# Altium schematic sheet Z5 - RF Board.SchDoc
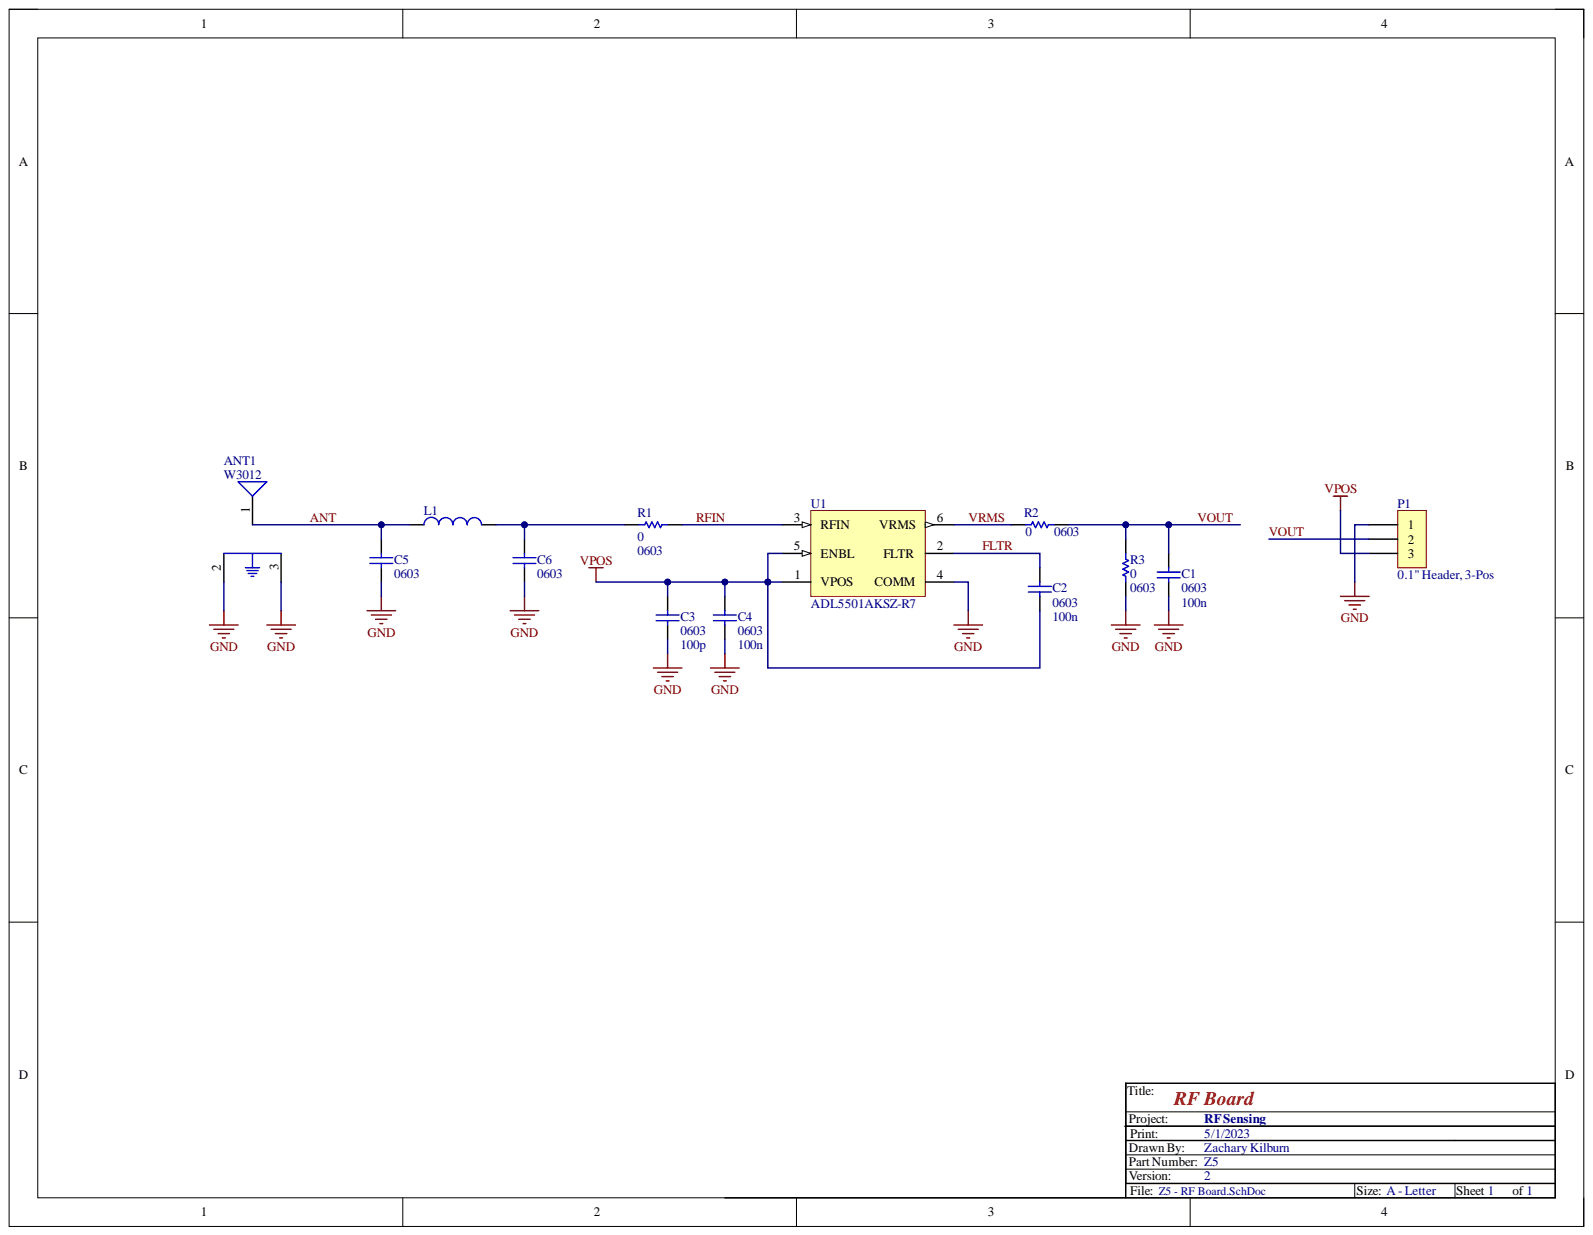
[diagram p0: Z5 - RF Board.SchDoc]

## Parts
ANT1  W3012  [W3012]
C1  value 100n  Case-EIA: 0603  [CAPC0603(1608)100_M]  (hidden comment: CAP 100nF 16V 0603(1608))
C2  value 100n  Case-EIA: 0603  [CAPC0603(1608)100_M]  (hidden comment: CAP 100nF 16V 0603(1608))
C3  value 100p  Case-EIA: 0603  [CAPC0603(1608)100_N]  (hidden comment: CAP 100pF 16V 0603(1608))
C4  value 100n  Case-EIA: 0603  [CAPC0603(1608)100_M]  (hidden comment: CAP 100nF 16V 0603(1608))
C5  Case-EIA: 0603  [CAPC0603(1608)100_L]  (hidden comment: CAP 1.5pF 16V 0603(1608))
C6  Case-EIA: 0603  [CAPC0603(1608)100_L]  (hidden comment: CAP 1.5pF 16V 0603(1608))
L1  Generic 0603 Inductor  [PCC-0603-IND-N]  (value not drawn)
P1  0.1" Header, 3-Pos  [3 Pin 0.1" R/A]
R1  value 0  Case-EIA: 0603  [RESC0603(1608)_N]  (hidden comment: Jumper 0603(1608))
R2  value 0  Case-EIA: 0603  [RESC0603(1608)_N]  (hidden comment: Jumper 0603(1608))
R3  value 0  Case-EIA: 0603  [RESC0603(1608)_N]  (hidden comment: Jumper 0603(1608))
U1  ADL5501AKSZ-R7  [ADI-KS-6_L]

## Nets
GND: ANT1.2 ANT1.3 C1.1 C3.2 C4.1 C5.1 C6.1 P1.1 R3.1 U1.4(COMM)
VPOS: C2.1 C3.1 C4.2 P1.3 U1.1(VPOS) U1.5(ENBL)
ANT: ANT1.1 C5.2 L1.1
FLTR: C2.2 U1.2(FLTR)
RFIN: R1.1 U1.3(RFIN)
VOUT: C1.2 P1.2 R2.1 R3.2
VRMS: R2.2 U1.6(VRMS)
NetC6_2: C6.2 L1.2 R1.2

## Library data of the parts
C1 C2 C4 = CMP-1035-02583-1: CAP 100nF 16V ±5% 0603 (1608 Metric) Thickness 1mm SMD; Case-Metric: 1608; Tolerance: Â±5%; Component Kind: Standard; Library Name: Altium Content Vault; Max Thickness: 1 mm; Rated Voltage: 16 V; Technology: SMT
C3 = CMP-1035-01257-1: CAP 100pF 16V ±1% 0603 (1608 Metric) Thickness 1mm SMD; Case-Metric: 1608; Tolerance: Â±1%; Component Kind: Standard; Library Name: Altium Content Vault; Max Thickness: 1 mm; Rated Voltage: 16 V; Technology: SMT
C5 C6 = CMP-1035-00091-1: CAP 1.5pF 16V ±0.1pF 0603 (1608 Metric) Thickness 1mm SMD; Case-Metric: 1608; Tolerance: Â±0.1pF; Component Kind: Standard; Library Name: Altium Content Vault; Max Thickness: 1 mm; Rated Voltage: 16 V; Technology: SMT; Value: 1.5pF
L1 = 0603 Inductor: Component Kind: Standard; Library Name: Inductors.SchLib; Package: 0603
P1 = 3-Pos 0.1" Header RA: Manufacturer: Samtec; PartNumber: TSW-103-08-F-S-RA; Component Kind: Standard; ComponentLink1Description: Datasheet; ComponentLink1URL: http://suddendocs.samtec.com/catalog_english/tsw_th.pdf; ComponentLink2Description: Package Specification; ComponentLink2URL: http://suddendocs.samtec.com/prints/tsw-xxx-xx-x-x-xx-xxx-footprint.pdf; Library Name: Headers.SchLib
R1-R3 = CMP-1012-00001-1: Jumper 0603 (1608 Metric); Case-Metric: 1608; Power: None; Component Kind: Standard; Library Name: Altium Content Vault; Technology: SMT; Tolerance: None
U1 = CMP-0284-00071-1: 50 MHz to 6 GHz TruPwr Detector, 6µs Response Time, KS-6, Pb-Free, 3000 Reel; Dynamic Range (dB): 30dB; Output Response: Linear in Voltage; Product Description: Reduced Size, Improved Temp Stability; Response Time (ns typ): 6Âµs; RF Frequency (MHz): 6GHz; Supply Current: 1.1mA; Temp Stability (dB): 250mdB; Voltage Supply (V): 2.7 to 5.5; Code_JEDEC: MO-203-AB; Component Kind: Standard; ComponentLink1Description: Manufacturer URL; ComponentLink1URL: www.analog.com; ComponentLink2Description: Datasheet; ComponentLink2URL: http://www.analog.com/static/imported-files/data_sheets/ADL5501.pdf; ComponentLink3Description: Package Specification; ComponentLink3URL: http://www.analog.com/static/imported-files/packages/PKG_PDF/SC70(KS)/KS_6.pdf; DatasheetVersion: Rev. B; Library Name: Altium Content Vault; PackageDescription: 6-Lead Thin Shrink Small Outline Transistor Package [SC70]; PackageReference: KS-6; PackageVersion: 072809-A
ANT1 = W3012: Component Kind: Standard; ComponentLink1Description: Datasheet; ComponentLink1URL: https://productfinder.pulseeng.com/doc_type/WEB301/doc_num/W3012/doc_part/W3012.pdf; Library Name: RF.SchLib
